# Altium schematic sheet 热敏电阻.SchDoc(热敏电阻)
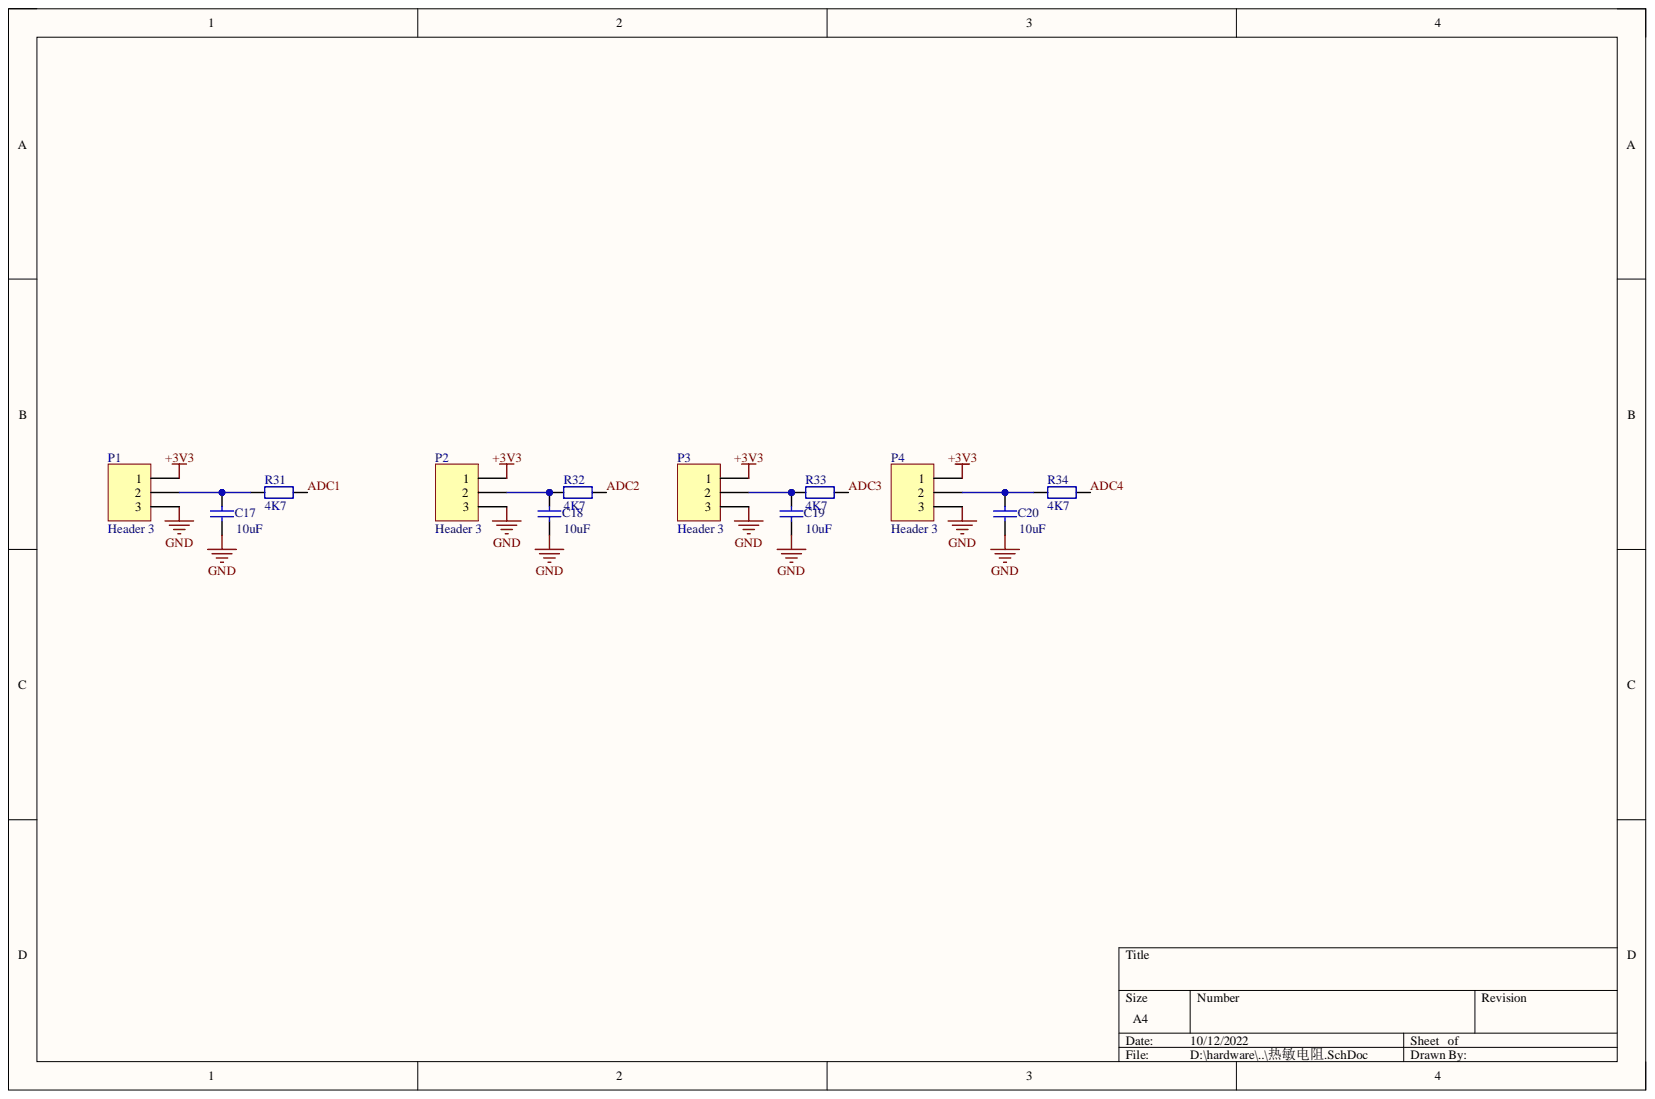
[diagram p0: 热敏电阻.SchDoc]

## Parts
C17  10uF  [0805c]
C18  10uF  [0805c]
C19  10uF  [0805c]
C20  10uF  [0805c]
P1  Header 3  [KF2EDG-2.54-3-H]
P2  Header 3  [KF2EDG-2.54-3-H]
P3  Header 3  [KF2EDG-2.54-3-H]
P4  Header 3  [KF2EDG-2.54-3-H]
R31  4K7  [0805r]
R32  4K7  [0805r]
R33  4K7  [0805r]
R34  4K7  [0805r]

## Nets
+3V3: P1.1 P2.1 P3.1 P4.1
GND: C17.1 C18.1 C19.1 C20.1 P1.3 P2.3 P3.3 P4.3
ADC1: R31.2
ADC2: R32.2
ADC3: R33.2
ADC4: R34.2
NetC17_2: C17.2 P1.2 R31.1
NetC18_2: C18.2 P2.2 R32.1
NetC19_2: C19.2 P3.2 R33.1
NetC20_2: C20.2 P4.2 R34.1

## Library data of the parts
C17-C20 = Cap: 无极性定值电容; Component Kind: Standard; LatestRevisionDate: 17-Jul-2002; LatestRevisionNote: Re-released for DXP Platform.; Library Name: 常用.SchLib; PackageDescription: Radial Cap, Thru-Hole; 2 Leads; 0.3 in Pin Spacing; PackageReference: RAD-0.3; Published: 8-Jun-2000; Signal Integrity: Cap; Simulation: CAP; Publisher: Altium Limited
P1-P4 = Header 3: Header, 3-Pin; Component Kind: Standard; LatestRevisionDate: 17-Jul-2002; LatestRevisionNote: Re-released for DXP Platform.; Signal Integrity: Connector; Library Name: 接插头.SchLib; Publisher: Altium Limited
R31-R34 = Res2: 定值电阻; Component Kind: Standard; LatestRevisionDate: 17-Jul-2002; LatestRevisionNote: Re-released for DXP Platform.; Library Name: 常用.SchLib; PackageDescription: Axial Device, Thru-Hole; 2 Leads; 0.4 in Pin Spacing; PackageReference: AXIAL-0.4; Published: 8-Jun-2000; Signal Integrity: Res; Simulation: RESISTOR; Publisher: Altium Limited
